# Altium schematic sheet Power.SchDoc(Power)
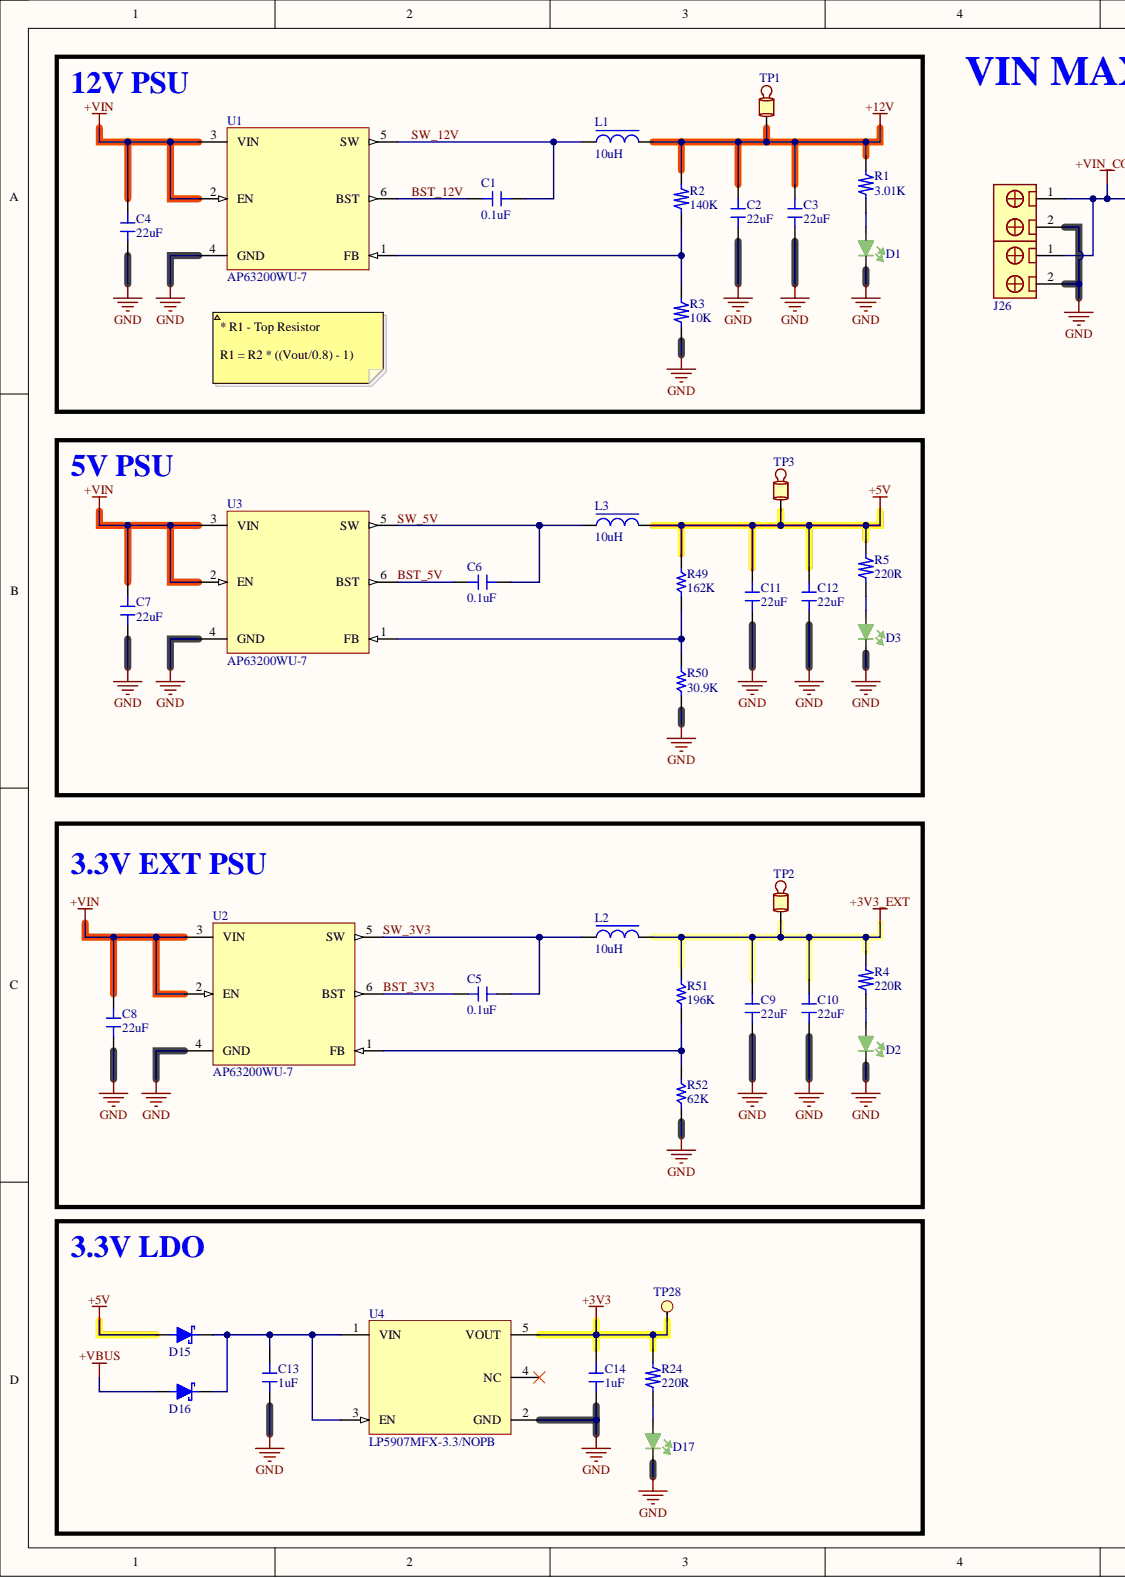
[diagram p0: Power.SchDoc, left]
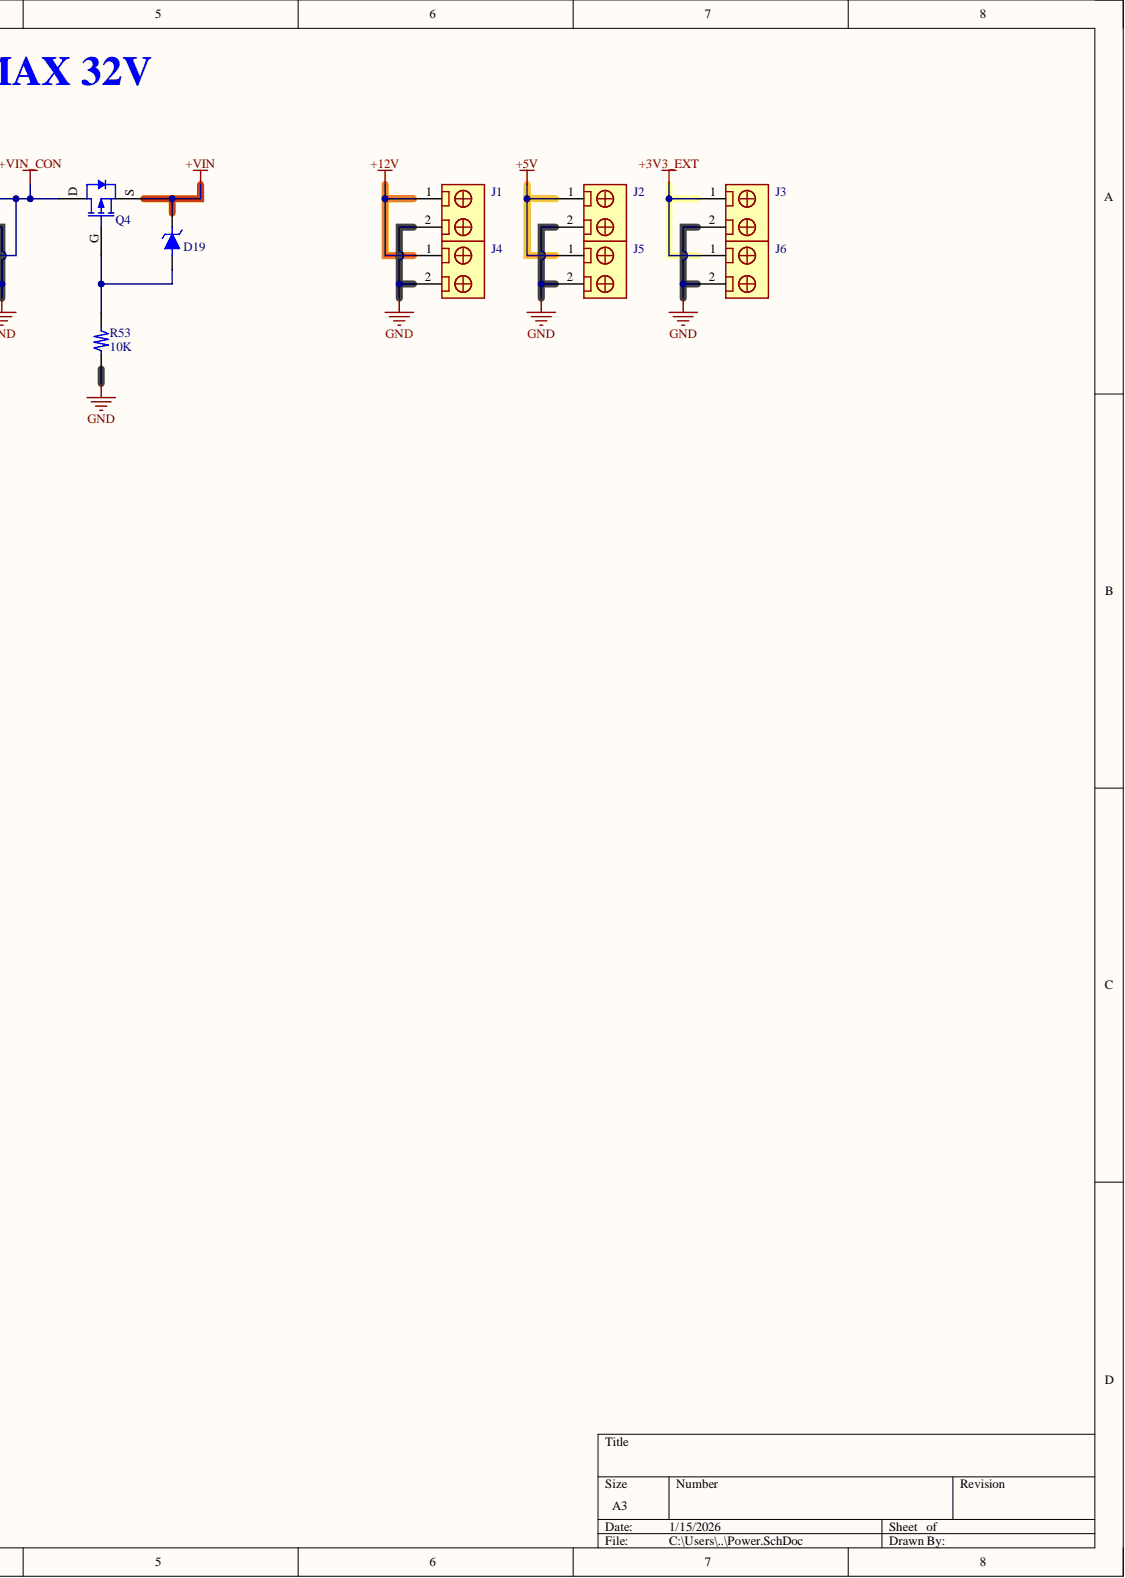
[diagram p1: Power.SchDoc, right]

## Parts
C1  value 0.1uF  [CAP0603]  (hidden comment: GRM188R72A104KA35D)
C2  value 22uF  [CAP1210]  (hidden comment: GRM32ER71E226KE15L)
C3  value 22uF  [CAP1210]  (hidden comment: GRM32ER71E226KE15L)
C4  value 22uF  [CAP1210]  (hidden comment: GRM32ER71E226KE15L)
C5  value 0.1uF  [CAP0603]  (hidden comment: GRM188R72A104KA35D)
C6  value 0.1uF  [CAP0603]  (hidden comment: GRM188R72A104KA35D)
C7  value 22uF  [CAP1210]  (hidden comment: GRM32ER71E226KE15L)
C8  value 22uF  [CAP1210]  (hidden comment: GRM32ER71E226KE15L)
C9  value 22uF  [CAP1210]  (hidden comment: GRM32ER71E226KE15L)
C10  value 22uF  [CAP1210]  (hidden comment: GRM32ER71E226KE15L)
C11  value 22uF  [CAP1210]  (hidden comment: GRM32ER71E226KE15L)
C12  value 22uF  [CAP1210]  (hidden comment: GRM32ER71E226KE15L)
C13  value 1uF  [CAP0805]  (hidden comment: GRM219R7YA105KA12D)
C14  value 1uF  [CAP0805]  (hidden comment: GRM219R7YA105KA12D)
D1  LG R971-KN-1-0-20-R18  [LED0805]  (value not drawn)
D2  LG R971-KN-1-0-20-R18  [LED0805]  (value not drawn)
D3  LG R971-KN-1-0-20-R18  [LED0805]  (value not drawn)
D15  B340AE-13  [SMA(DO-214AC)]  (value not drawn)
D16  B340AE-13  [SMA(DO-214AC)]  (value not drawn)
D17  LG R971-KN-1-0-20-R18  [LED0805]  (value not drawn)
D19  BZT52C9V1-7-F  [SOD123]  (value not drawn)
J1  1935161  [1935161]  (value not drawn)
J2  1935161  [1935161]  (value not drawn)
J3  1935161  [1935161]  (value not drawn)
J4  1935161  [1935161]  (value not drawn)
J5  1935161  [1935161]  (value not drawn)
J6  1935161  [1935161]  (value not drawn)
J20  1935161  [1935161]  (value not drawn)
J26  1935161  [1935161]  (value not drawn)
L1  value 10uH  [SRN6540]  (hidden comment: SRP6540-100M)
L2  value 10uH  [SRN6540]  (hidden comment: SRP6540-100M)
L3  value 10uH  [SRN6540]  (hidden comment: SRP6540-100M)
Q4  DMPH6050SK3-13  [TO252(DPAK)]  (value not drawn)
R1  value 3.01K  [RES0603]  (hidden comment: RC0603FR-073K01L)
R2  value 140K  [RES0603]  (hidden comment: RC0603FR-07140KL)
R3  value 10K  [RES0603]  (hidden comment: RC0603FR-0710KL)
R4  value 220R  [RES0603]  (hidden comment: RC0603FR-07220RL)
R5  value 220R  [RES0603]  (hidden comment: RC0603FR-07220RL)
R24  value 220R  [RES0603]  (hidden comment: RC0603FR-07220RL)
R49  value 162K  [RES0603]  (hidden comment: RC0603FR-07162KL)
R50  value 30.9K  [RES0603]  (hidden comment: RC0603FR-0730K9L)
R51  value 196K  [RES0603]  (hidden comment: RC0603FR-07196KL)
R52  value 62K  [RES0603]  (hidden comment: RC0603FR-0762KL)
R53  value 10K  [RES0603]  (hidden comment: RC0603FR-0710KL)
TP1  5001  [5001]  (value not drawn)
TP2  5001  [5001]  (value not drawn)
TP3  5001  [5001]  (value not drawn)
TP28  TP-0.5x0.9MM  [TP-0.5x0.9MM]  (value not drawn)  (no BOM)
U1  AP63200WU-7  [TSOT26 (TSOT-23-6)]
U2  AP63200WU-7  [TSOT26 (TSOT-23-6)]
U3  AP63200WU-7  [TSOT26 (TSOT-23-6)]
U4  LP5907MFX-3.3/NOPB  [SOT23-5]

## Nets
+3V3: C14.1 R24.1 TP28.1 U4.5(VOUT)
+3V3_EXT: C9.1 C10.1 J3.1 J6.1 L2.2 R4.1 R51.1 TP2.1
+5V: C11.1 C12.1 D15.A J2.1 J5.1 L3.2 R5.1 R49.1 TP3.1
+12V: C2.1 C3.1 J1.1 J4.1 L1.2 R1.1 R2.1 TP1.1
+VBUS: D16.A
+VIN: C4.1 C7.1 C8.1 D19.K Q4.S U1.2(EN) U1.3(VIN) U2.2(EN) U2.3(VIN) U3.2(EN) U3.3(VIN)
+VIN_CON: J20.1 J26.1 Q4.D
GND: C2.2 C3.2 C4.2 C7.2 C8.2 C9.2 C10.2 C11.2 C12.2 C13.2 C14.2 D1.K D2.K D3.K D17.K J1.2 J2.2 J3.2 J4.2 J5.2 J6.2 J20.2 J26.2 R3.2 R50.2 R52.2 R53.2 U1.4(GND) U2.4(GND) U3.4(GND) U4.2(GND)
BST_3V3: C5.1 U2.6(BST)
BST_5V: C6.2 U3.6(BST)
BST_12V: C1.1 U1.6(BST)
SW_3V3: C5.2 L2.1 U2.5(SW)
SW_5V: C6.1 L3.1 U3.5(SW)
SW_12V: C1.2 L1.1 U1.5(SW)
NetC13_1: C13.1 D15.K D16.K U4.1(VIN) U4.3(EN)
NetD1_A: D1.A R1.2
NetD2_A: D2.A R4.2
NetD3_A: D3.A R5.2
NetD17_A: D17.A R24.2
NetD19_A: D19.A Q4.G R53.1
NetR2_2: R2.2 R3.1 U1.1(FB)
NetR49_2: R49.2 R50.1 U3.1(FB)
NetR51_2: R51.2 R52.1 U2.1(FB)

Not connected: U4 4(NC)

## Library data of the parts
C1 C5 C6 = GRM188R72A104KA35D: 0.1 µF ±10% 100V Ceramic Capacitor X7R 0603 (1608 Metric); Manufacturer: Murata Electronics; Component Kind: Standard; ComponentLink1Description: Datasheet; ComponentLink1URL: https://search.murata.co.jp/Ceramy/image/img/A01X/G101/ENG/GRM188R72A104KA35-01.pdf; ComponentLink2Description: DigiKey Link; ComponentLink2URL: https://www.digikey.com/en/products/detail/murata-electronics/GRM188R72A104KA35D/702549; ComponentLink3Description: LCSC Link; ComponentLink3URL: https://lcsc.com/product-detail/Multilayer-Ceramic-Capacitors-MLCC-SMD-SMT_muRata-GRM188R72A104KA35D_C77058.html?s_z=n_GRM188R72A104KA35D; DigiKey PN: 490-3285-1-ND; LCSC PN: C77058; Library Name: 01-Capacitors_0603.IntLib; MPN: GRM188R72A104KA35D; Package: 0603; Temp Coefficient: X7R; Tolerance: ±10%; Voltage Rating: 100V
C2-C4 C7-C12 = GRM32ER71E226KE15L: 22 µF ±10% 25V Ceramic Capacitor X7R 1210 (3225 Metric); Manufacturer: Murata Electronics; Component Kind: Standard; ComponentLink1Description: Datasheet; ComponentLink1URL: https://search.murata.co.jp/Ceramy/image/img/A01X/G101/ENG/GRM32ER71E226KE15-01.pdf; ComponentLink2Description: DigiKey Link; ComponentLink2URL: https://www.digikey.co.il/en/products/detail/murata-electronics/GRM32ER71E226KE15L/2039040?s=N4IgTCBcDaIOICUCyBmMBRBB2AjOsYAbANLo4CsAMiALoC%2BQA; ComponentLink3Description: LCSC Link; ComponentLink3URL: https://lcsc.com/product-detail/Multilayer-Ceramic-Capacitors-MLCC-SMD-SMT_Murata-Electronics-GRM32ER71E226KE15L_C21397.html; DigiKey PN: 490-5313-1-ND; LCSC PN: C21397; Library Name: 01-Capacitors_1210.IntLib; MPN: GRM32ER71E226KE15L; Package: 1210; Temp Coefficient: X7R; Tolerance: ±10%; Voltage Rating: 25V
C13 C14 = GRM219R7YA105KA12D: 1 µF ±10% 35V Ceramic Capacitor X7R 0805 (2012 Metric); Manufacturer: Murata Electronics; Component Kind: Standard; ComponentLink1Description: Datasheet; ComponentLink1URL: https://search.murata.co.jp/Ceramy/image/img/A01X/G101/ENG/GRM219R7YA105KA12-01.pdf; ComponentLink2Description: DigiKey Link; ComponentLink2URL: https://www.digikey.com/en/products/detail/murata-electronics/GRM219R7YA105KA12D/5027579; ComponentLink3Description: LCSC Link; ComponentLink3URL: https://lcsc.com/product-detail/Multilayer-Ceramic-Capacitors-MLCC-SMD-SMT_muRata-GRM219R7YA105KA12D_C440196.html?s_z=n_GRM219R7YA105KA12D; DigiKey PN: 490-10494-1-ND; LCSC PN: C440196; Library Name: 01-Capacitors_0805.IntLib; MPN: GRM219R7YA105KA12D; Package: 0805; Temp Coefficient: X7R; Tolerance: ±10%; Voltage Rating: 35V
D1-D3 D17 = LG R971-KN-1-0-20-R18: Green 570nm LED Indication - Discrete 2.2V 0805 (2012 Metric); Manufacturer: ams-OSRAM USA INC.; Component Kind: Standard; ComponentLink1Description: Datasheet; ComponentLink1URL: https://look.ams-osram.com/m/2da6f2ceeebf8fac/original/LG-R971.pdf; ComponentLink2Description: DigiKey Link; ComponentLink2URL: https://www.digikey.com/en/products/detail/ams-osram-usa-inc/LG-R971-KN-1-0-20-R18/1227925?s=N4IgTCBcDaIDIHEAEAlAnAdgIwFoDSAcjrgAw5hkpYAcIAugL5A; ComponentLink3Description: LCSC Link; ComponentLink3URL: https://lcsc.com/product-detail/LED-Indication-Discrete_OSRAM-LG-R971-KN-1-0-20-R18_C2899888.html?s_z=n_LG%2520R971-KN-1-0-20-R18; DigiKey PN: 475-LGR971-KN-1CT-ND; LCSC PN: C2899888; Library Name: 05-Optoelectronics.IntLib; MPN: LG R971-KN-1-0-20-R18; Package: 0805
D15 D16 = B340AE-13: DIODE SCHOTTKY 40V 3A SMA; Manufacturer: Diodes Incorporated; Component Kind: Standard; ComponentLink1Description: Datasheet; ComponentLink1URL: https://www.diodes.com/assets/Datasheets/B320AE/B340AE.pdf; ComponentLink2Description: DigiKey Link; ComponentLink2URL: https://www.digikey.com/en/products/detail/diodes-incorporated/B340AE-13/7352831; ComponentLink3Description: LCSC Link; ComponentLink3URL: https://lcsc.com/product-detail/Schottky-Diodes_Diodes-Incorporated-B340AE-13_C168859.html?s_z=n_B340AE-13; DigiKey PN: B340AE-13DICT-ND; LCSC PN: C168859; Library Name: 02-Diodes.IntLib; MPN: B340AE-13; Package: SMA(DO-214AC)
D19 = BZT52C9V1-7-F: DIODE ZENER 9.1V 500MW SOD123; Manufacturer: Diodes Incorporated; Component Kind: Standard; ComponentLink1Description: Datasheet; ComponentLink1URL: https://www.diodes.com/assets/Datasheets/ds18004.pdf; ComponentLink2Description: DigiKey Link; ComponentLink2URL: https://www.digikey.com/en/products/detail/diodes-incorporated/BZT52C9V1-7-F/717742?s=N4IgTCBcDaICIEsD2ATApgZwAQEkB2AxkgE4AOJAhgC5opYBCAWgCoCsYAwgJwBqAjAFoA7AIBiIALoBfIA; ComponentLink3Description: LCSC Link; ComponentLink3URL: https://lcsc.com/product-detail/Zener-Diodes_Diodes-Incorporated-BZT52C9V1-7-F_C260913.html?s_z=n_BZT52C9V1; DigiKey PN: BZT52C9V1-FDICT-ND; LCSC PN: C260913; Library Name: 02-Diodes.IntLib; MPN: BZT52C9V1-7-F; Package: SOD123
J1-J6 J20 J26 = 1935161: TB 5.00mm 2POS TH 18A, 300V, 12-26 AWG Wire; Manufacturer: Phoenix Contact; Component Kind: Standard; ComponentLink1Description: Datasheet; ComponentLink1URL: https://mm.digikey.com/Volume0/opasdata/d220001/medias/docus/909/1935161.pdf; ComponentLink2Description: DigiKey Link; ComponentLink2URL: https://www.digikey.com/en/products/detail/phoenix-contact/1935161/568614; ComponentLink3Description: LCSC Link; ComponentLink3URL: https://www.lcsc.com/product-detail/C3819953.html?s_z=n_1935161; DigiKey PN: 277-1667-ND; LCSC PN: C3819953; Library Name: 11-Connectors_5.00mm.IntLib; MPN: 1935161; Series: COMBICON PT
L1-L3 = SRP6540-100M: 10 µH Shielded Drum Core, Wirewound Inductor 4 A 78.5mOhm Max Nonstandard; Manufacturer: Bourns Inc.; Component Kind: Standard; ComponentLink1Description: Datasheet; ComponentLink1URL: https://www.bourns.com/docs/Product-Datasheets/SRP6540.pdf; ComponentLink2Description: DigiKey Link; ComponentLink2URL: https://www.digikey.com/en/products/detail/bourns-inc/SRP6540-100M/3986099?s=N4IgTCBcDaIMoCUAKA2ArAFgAwFoCMWWAsiALoC%2BQA; ComponentLink3Description: LCSC Link; ComponentLink3URL: https://www.lcsc.com/product-detail/C780191.html; DigiKey PN: SRP6540-100MCT-ND; LCSC PN: C780191; Library Name: 04-Inductors.IntLib; MPN: SRP6540-100M; Package: 7.2x6.5mm
Q4 = DMPH6050SK3-13: MOSFET P-CH 60V 7.2A/23.6A TO252; Manufacturer: Diodes Incorporated; Component Kind: Standard; ComponentLink1Description: Datasheet; ComponentLink1URL: https://www.diodes.com/assets/Datasheets/DMPH6050SK3.pdf; ComponentLink2Description: DigiKey Link; ComponentLink2URL: https://www.digikey.com/en/products/detail/diodes-incorporated/DMPH6050SK3-13/6010147?s=N4IgTCBcDaICIFkAKAJAbABgKwYMoGkBmAWgEZCQBdAXyA; ComponentLink3Description: LCSC Link; ComponentLink3URL: https://lcsc.com/product-detail/MOSFETs_Diodes-Incorporated-DMPH6050SK3-13_C260900.html; DigiKey PN: DMPH6050SK3-13DICT-ND; LCSC PN: C260900; Library Name: 03-Transistors_MOSFETS.IntLib; MPN: DMPH6050SK3-13; Package: TO252(DPAK)
R1 = RC0603FR-073K01L: 3.01 kOhms ±1% 0.1W, 1/10W Chip Resistor 0603 (1608 Metric) Moisture Resistant Thick Film; Manufacturer: YAGEO; Component Kind: Standard; ComponentLink1Description: Datasheet; ComponentLink1URL: https://www.yageo.com/upload/media/product/products/datasheet/rchip/PYu-RC_Group_51_RoHS_L_12.pdf; ComponentLink2Description: DigiKey Link; ComponentLink2URL: https://www.digikey.com/en/products/detail/yageo/RC0603FR-073K01L/727121?s=N4IgTCBcDaIEoGEAMA2JBmAYnAtEg7OgNJICMAMiALoC%2BQA; ComponentLink3Description: LCSC Link; ComponentLink3URL: https://lcsc.com/product-detail/Chip-Resistor-Surface-Mount_YAGEO-RC0603FR-073K01L_C137732.html?s_z=n_RC0603FR-073K01L; DigiKey PN: 311-3.01KHRCT-ND; LCSC PN: C137732; Library Name: 00-Resistors_0603.IntLib; MPN: RC0603FR-073K01L; Package: 0603
R2 = RC0603FR-07140KL: 140 kOhms ±1% 0.1W, 1/10W Chip Resistor 0603 (1608 Metric) Moisture Resistant Thick Film; Manufacturer: YAGEO; Component Kind: Standard; ComponentLink1Description: Datasheet; ComponentLink1URL: https://www.yageo.com/upload/media/product/products/datasheet/rchip/PYu-RC_Group_51_RoHS_L_12.pdf; ComponentLink2Description: DigiKey Link; ComponentLink2URL: https://www.digikey.com/en/products/detail/yageo/RC0603FR-07140KL/726947?s=N4IgTCBcDaIEoGEAMA2JBmAYnAtEg7AIwAsSA0gDIgC6AvkA; ComponentLink3Description: LCSC Link; ComponentLink3URL: https://www.lcsc.com/product-detail/C185372.html?s_z=n_RC0603FR-07140KL; DigiKey PN: 311-140KHRCT-ND; LCSC PN: C185372; Library Name: 00-Resistors_0603.IntLib; MPN: RC0603FR-07140KL; Package: 0603
R3 R53 = RC0603FR-0710KL: 10 kOhms ±1% 0.1W, 1/10W Chip Resistor 0603 (1608 Metric) Moisture Resistant Thick Film; Manufacturer: YAGEO; Component Kind: Standard; ComponentLink1Description: Datasheet; ComponentLink1URL: https://www.yageo.com/upload/media/product/products/datasheet/rchip/PYu-RC_Group_51_RoHS_L_12.pdf; ComponentLink2Description: DigiKey Link; ComponentLink2URL: https://www.digikey.com/en/products/detail/yageo/RC0603FR-0710KL/726880?s=N4IgTCBcDaIEoGEAMA2JBmAYnAtEg7AIxIDSAMiALoC%2BQA; ComponentLink3Description: LCSC Link; ComponentLink3URL: https://lcsc.com/product-detail/Chip-Resistor-Surface-Mount_YAGEO-RC0603FR-0710KL_C98220.html?s_z=n_RC0603FR-0710KL; DigiKey PN: 311-10.0KHRCT-ND; LCSC PN: C98220; Library Name: 00-Resistors_0603.IntLib; MPN: RC0603FR-0710KL; Package: 0603
R4 R5 R24 = RC0603FR-07220RL: 220 Ohms ±1% 0.1W, 1/10W Chip Resistor 0603 (1608 Metric) Moisture Resistant Thick Film; Manufacturer: YAGEO; Component Kind: Standard; ComponentLink1Description: Datasheet; ComponentLink1URL: https://www.yageo.com/upload/media/product/products/datasheet/rchip/PYu-RC_Group_51_RoHS_L_12.pdf; ComponentLink2Description: DigiKey Link; ComponentLink2URL: https://www.digikey.com/en/products/detail/yageo/RC0603FR-07220RL/727061?s=N4IgTCBcDaIEoGEAMA2JBmAYnAtEg7GGEnADIgC6AvkA; ComponentLink3Description: LCSC Link; ComponentLink3URL: https://lcsc.com/product-detail/Chip-Resistor-Surface-Mount_YAGEO-RC0603FR-07220RL_C107696.html?s_z=n_RC0603FR-07220RL; DigiKey PN: 311-220HRCT-ND; LCSC PN: C107696; Library Name: 00-Resistors_0603.IntLib; MPN: RC0603FR-07220RL; Package: 0603
R49 = RC0603FR-07162KL: 162 kOhms ±1% 0.1W, 1/10W Chip Resistor 0603 (1608 Metric) Moisture Resistant Thick Film; Manufacturer: YAGEO; Component Kind: Standard; ComponentLink1Description: Datasheet; ComponentLink1URL: https://www.yageogroup.com/content/datasheet/asset/file/PYU-RC_GROUP_51_ROHS_L; ComponentLink2Description: DigiKey Link; ComponentLink2URL: https://www.digikey.com/en/products/detail/yageo/RC0603FR-07162KL/726975?s=N4IgTCBcDaIE4GMAMA2JBmAZnAtEg7AIwpgDWANiALoC%2BQA; ComponentLink3Description: LCSC Link; ComponentLink3URL: https://www.lcsc.com/product-detail/C165760.html?s_z=n_RC0603FR-07162KL; DigiKey PN: 311-162KHRCT-ND; LCSC PN: C165760; Library Name: 00-Resistors_0603.IntLib; MPN: RC0603FR-07162KL; Package: 0603
R50 = RC0603FR-0730K9L: 30.9 kOhms ±1% 0.1W, 1/10W Chip Resistor 0603 (1608 Metric) Moisture Resistant Thick Film; Manufacturer: YAGEO; Component Kind: Standard; ComponentLink1Description: Datasheet; ComponentLink1URL: https://www.yageogroup.com/content/datasheet/asset/file/PYU-RC_GROUP_51_ROHS_L; ComponentLink2Description: DigiKey Link; ComponentLink2URL: https://www.digikey.com/en/products/detail/yageo/RC0603FR-0730K9L/727144?s=N4IgTCBcDaIEoGEAMA2JBmAYnAtEg7OkgNICcAMiALoC%2BQA; ComponentLink3Description: LCSC Link; ComponentLink3URL: https://www.lcsc.com/product-detail/C137744.html?s_z=n_RC0603FR-0730K9L; DigiKey PN: 311-30.9KHRCT-ND; LCSC PN: C137744; Library Name: 00-Resistors_0603.IntLib; MPN: RC0603FR-0730K9L; Package: 0603
R51 = RC0603FR-07196KL: 196 kOhms ±1% 0.1W, 1/10W Chip Resistor 0603 (1608 Metric) Moisture Resistant Thick Film; Manufacturer: YAGEO; Component Kind: Standard; ComponentLink1Description: Datasheet; ComponentLink1URL: https://www.yageogroup.com/content/datasheet/asset/file/PYU-RC_GROUP_51_ROHS_L; ComponentLink2Description: DigiKey Link; ComponentLink2URL: https://www.digikey.com/en/products/detail/yageo/RC0603FR-07196KL/727007?s=N4IgTCBcDaIE4GMAMA2JBmAZnAtEg7AIwCcKA1gDYgC6AvkA; ComponentLink3Description: LCSC Link; ComponentLink3URL: https://www.lcsc.com/product-detail/C273761.html?s_z=n_rc0603fr-07196kl; DigiKey PN: 311-196KHRCT-ND; LCSC PN: C273761; Library Name: 00-Resistors_0603.IntLib; MPN: RC0603FR-07196KL; Package: 0603
R52 = RC0603FR-0762KL: 62 kOhms ±1% 0.1W, 1/10W Chip Resistor 0603 (1608 Metric) Moisture Resistant Thick Film; Manufacturer: YAGEO; Component Kind: Standard; ComponentLink1Description: Datasheet; ComponentLink1URL: https://www.yageogroup.com/content/datasheet/asset/file/PYU-RC_GROUP_51_ROHS_L; ComponentLink2Description: DigiKey Link; ComponentLink2URL: https://www.digikey.com/en/products/detail/yageo/RC0603FR-0762KL/727336?s=N4IgTCBcDaIE4GMAMA2JBmAZnAtEg7CmANYA2IAugL5A; ComponentLink3Description: LCSC Link; ComponentLink3URL: https://www.lcsc.com/product-detail/C137694.html?s_z=n_RC0603FR-0762KL; DigiKey PN: 311-62.0KHRCT-ND; LCSC PN: C137694; Library Name: 00-Resistors_0603.IntLib; MPN: RC0603FR-0762KL; Package: 0603
TP1-TP3 = 5001: TEST POINT RING, BACK - TH; Manufacturer: Keystone Electronics; Component Kind: Standard; ComponentLink1Description: Datasheet; ComponentLink1URL: https://www.keyelco.com/userAssets/file/M65p56.pdf; ComponentLink2Description: DigiKey Link; ComponentLink2URL: https://www.digikey.com/en/products/detail/keystone-electronics/5001/255327; ComponentLink3Description: LCSC Link; ComponentLink3URL: https://www.lcsc.com/product-detail/C238122.html; DigiKey PN: 36-5001-ND; LCSC PN: C238122; Library Name: 09-TestPoints.IntLib; MPN: 5001
U1-U3 = AP63200WU-7: IC REG BUCK ADJ 2A TSOT23-6; Manufacturer: Diodes Incorporated; Component Kind: Standard; ComponentLink1Description: Datasheet; ComponentLink1URL: https://www.diodes.com/assets/Datasheets/AP63200-AP63201-AP63203-AP63205.pdf; ComponentLink2Description: DigiKey Link; ComponentLink2URL: https://www.digikey.com/en/products/detail/diodes-incorporated/AP63200WU-7/9858423?s=N4IgTCBcDaIIIAUBsBmMAGdB1AqgWgHYQBdAXyA; ComponentLink3Description: LCSC Link; ComponentLink3URL: https://www.lcsc.com/product-detail/C2071868.html?s_z=n_AP63200WU-7; DigiKey PN: AP63200WU-7DICT-ND; LCSC PN: C2071868; MPN: AP63200WU-7; Package: TSOT26(TSOT-23-6); Library Name: 06-Power_Managment.IntLib
U4 = LP5907MFX-3.3/NOPB: Linear Voltage Regulator IC Positive Fixed 1 Output 250mA SOT-23-5; Manufacturer: STMicroelectronics; Component Kind: Standard; ComponentLink1Description: Datasheet; ComponentLink1URL: https://www.ti.com/lit/ds/symlink/lp5907.pdf; ComponentLink2Description: DigiKey Link; ComponentLink2URL: https://www.digikey.com/en/products/detail/texas-instruments/LP5907MFX-3-3-NOPB/3906441; ComponentLink3Description: LCSC Link; ComponentLink3URL: https://www.lcsc.com/product-detail/C80670.html?s_z=n_LP5907MFX-3.3%252FNOPB; DigiKey PN: 296-38557-1-ND; LCSC PN: C80670; MPN: LP5907MFX-3.3/NOPB; Package: SOT-23-5; Library Name: 06-Power_Managment.IntLib
TP28 = TP-0.5x0.9MM: Description: TP-0.5x0.9MM; Library Name: 09-TestPoints_And_Pads.IntLib
